# Altium schematic sheet voltage_sampling.SchDoc(voltage_sampling)
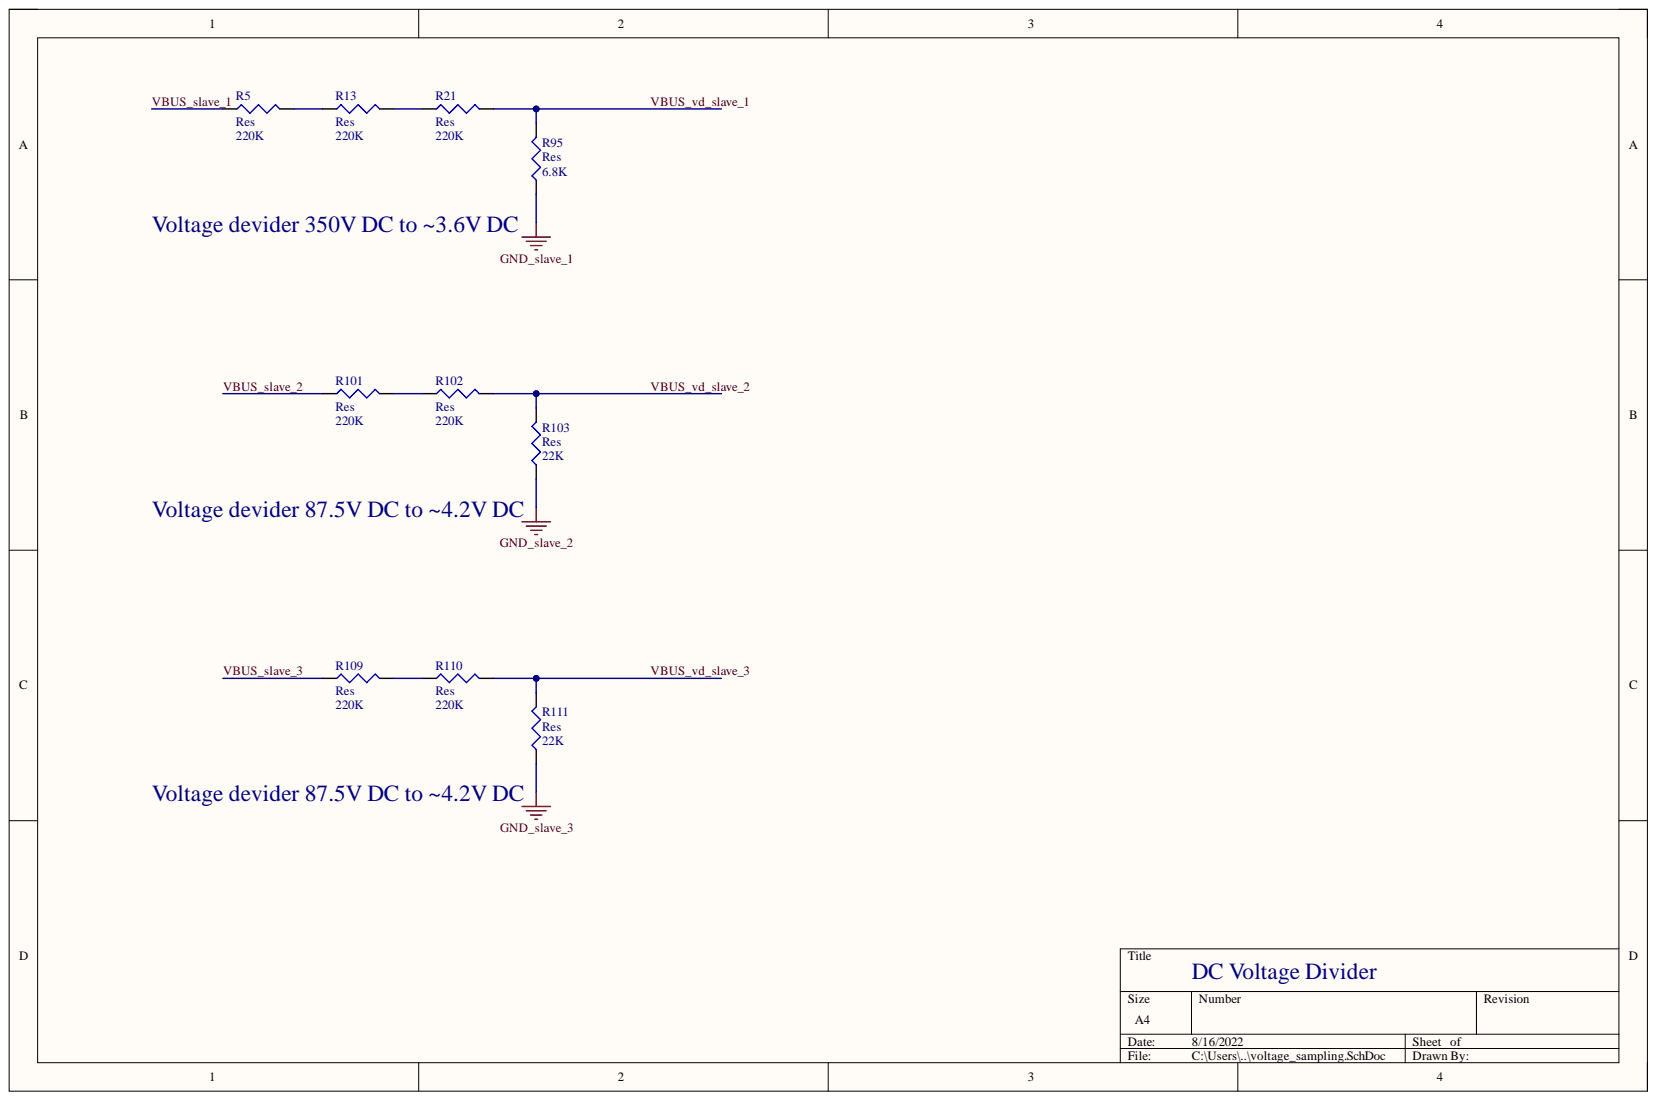
[diagram p0: voltage_sampling.SchDoc]

## Parts
R5  Res  value 220K  [RES0805W]
R13  Res  value 220K  [RES0805W]
R21  Res  value 220K  [RES0805W]
R95  Res  value 6.8K  [RES0805W]
R101  Res  value 220K  [RES0805W]
R102  Res  value 220K  [RES0805W]
R103  Res  value 22K  [RES0805W]
R109  Res  value 220K  [RES0805W]
R110  Res  value 220K  [RES0805W]
R111  Res  value 22K  [RES0805W]

## Nets
GND_slave_1: R95.1
GND_slave_2: R103.1
GND_slave_3: R111.1
VBUS_slave_1: R5.1
VBUS_slave_2: R101.1
VBUS_slave_3: R109.1
VBUS_vd_slave_1: R21.2 R95.2
VBUS_vd_slave_2: R102.2 R103.2
VBUS_vd_slave_3: R110.2 R111.2
NetR5_2: R5.2 R13.1
NetR13_2: R13.2 R21.1
NetR101_2: R101.2 R102.1
NetR109_2: R109.2 R110.1

## Library data of the parts
R5 R13 R21 R95 R101-R103 R109-R111 = Res3: Resistor; Component Kind: Standard; LatestRevisionDate: 29-May-2009; LatestRevisionNote: IPC-7351 Footprint Added.; Library Name: Miscellaneous Devices.IntLib; PackageDescription: Chip Resistor; PackageReference: J1-0603; Published: 8-Jun-2000; Signal Integrity: Res; Simulation: RESISTOR; Publisher: Altium Limited
